# Altium schematic sheet ReedSWDB.SchDoc
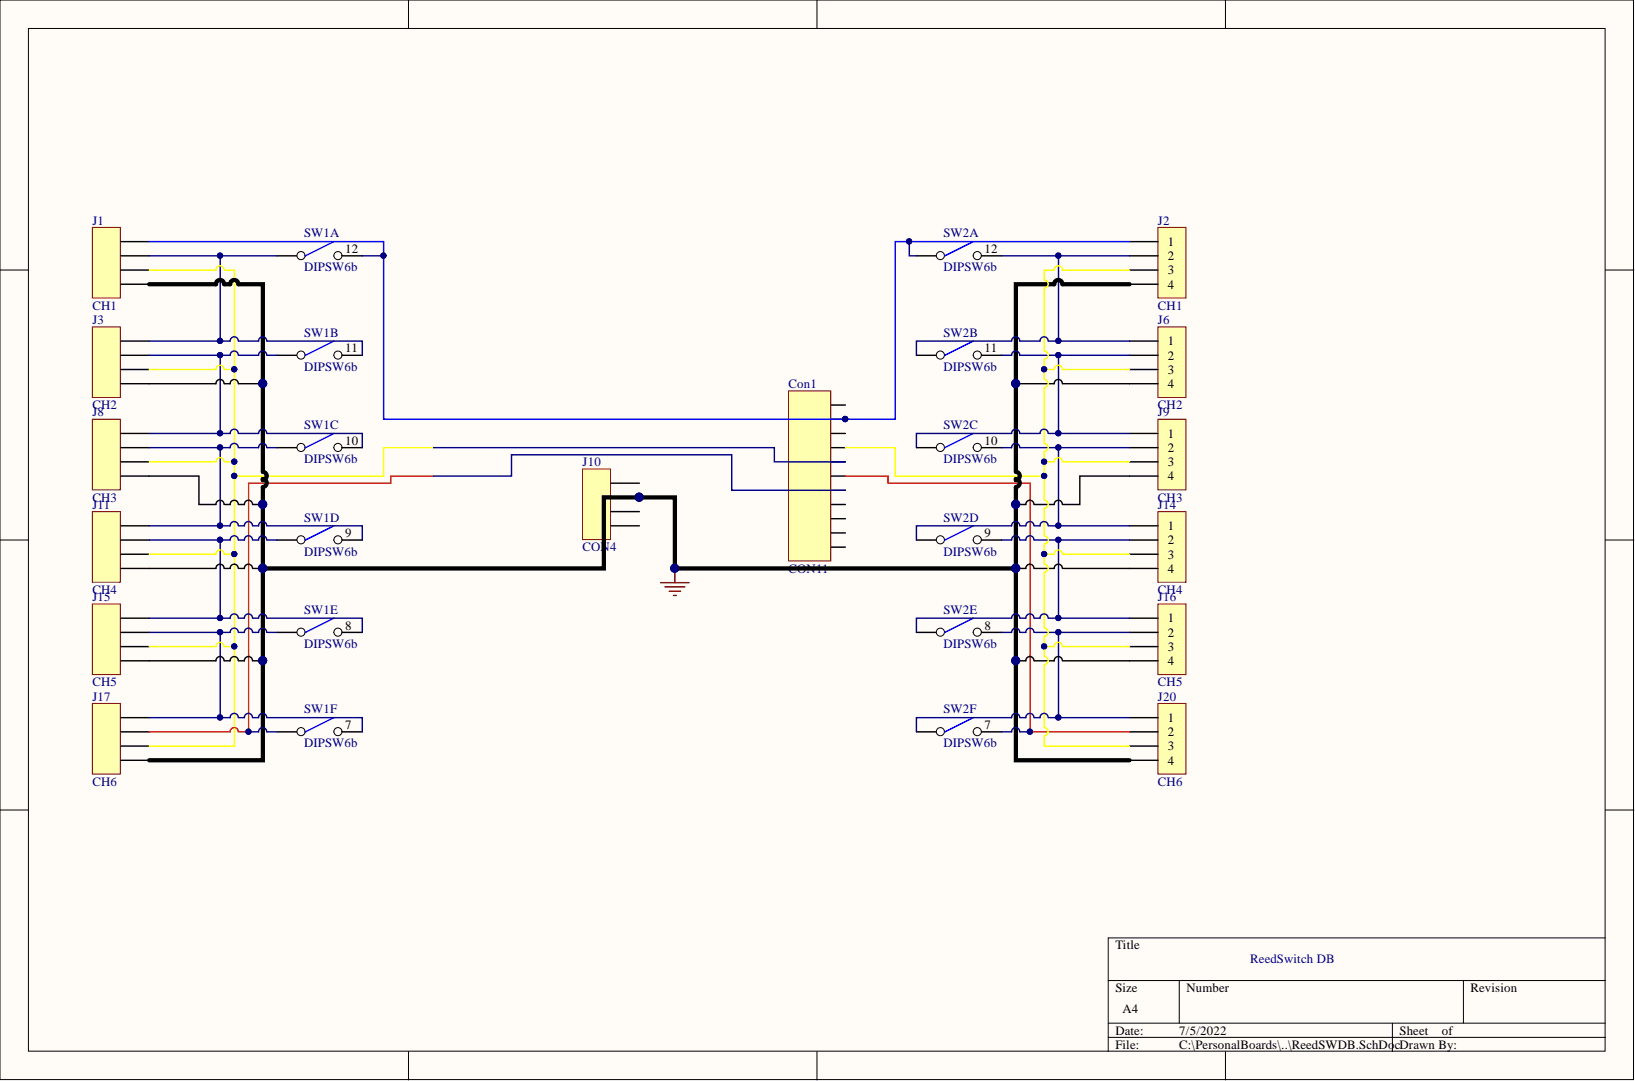
[diagram p0: ReedSWDB.SchDoc]

## Parts
Con1  CON11  [SIP-11]
J1  CH1  [con4_SR]
J2  CH1  [con4_SR]
J3  CH2  [con4_SR]
J6  CH2  [con4_SR]
J8  CH3  [con4_SR]
J9  CH3  [con4_SR]
J10  CON4  [SIP-4]
J11  CH4  [con4_SR]
J14  CH4  [con4_SR]
J15  CH5  [con4_SR]
J16  CH5  [con4_SR]
J17  CH6  [con4_SR]
J20  CH6  [con4_SR]
SW1  DIPSW6b  [Dipswitch6]  (parts A,B,C,D,E,F)
SW2  DIPSW6b  [Dipswitch6]  (parts A,B,C,D,E,F)

## Nets
GND: J1.4 J2.4 J3.4 J6.4 J8.4 J9.4 J10.2 J11.4 J14.4 J15.4 J16.4 J17.4 J20.4
NetCon1_2: Con1.2 J1.1 J2.1 SW1.12 SW2.1
NetCon1_4: Con1.4 J2.3 J6.3 J9.3 J14.3 J16.3 J20.3
NetCon1_5: Con1.5 J1.3 J3.3 J8.3 J11.3 J15.3 J17.3
NetCon1_6: Con1.6 J20.2 SW2.7
NetCon1_7: Con1.7 J17.2 SW1.6
NetJ1_2: J1.2 J3.1 SW1.1 SW1.11
NetJ2_2: J2.2 J6.1 SW2.2 SW2.12
NetJ3_2: J3.2 J8.1 SW1.2 SW1.10
NetJ6_2: J6.2 J9.1 SW2.3 SW2.11
NetJ8_2: J8.2 J11.1 SW1.3 SW1.9
NetJ9_2: J9.2 J14.1 SW2.4 SW2.10
NetJ11_2: J11.2 J15.1 SW1.4 SW1.8
NetJ14_2: J14.2 J16.1 SW2.5 SW2.9
NetJ15_2: J15.2 J17.1 SW1.5 SW1.7
NetJ16_2: J16.2 J20.1 SW2.6 SW2.8

Not connected: Con1 1 3 8 9 10 11; J10 1 3 4

## Library data of the parts
J1-J3 J6 J8-J11 J14-J17 J20 = CON4: Connector; Component Kind: Standard; Library Name: Miscellaneous Devices.SchLib
Con1 = CON11: Component Kind: Standard; Library Name: Miscellaneous Devices.SchLib
SW1 SW2 = DIPSW6b: Component Kind: Standard; Library Name: Miscellaneous Devices.SchLib
